# Altium schematic sheet baocaodoan.SchDoc
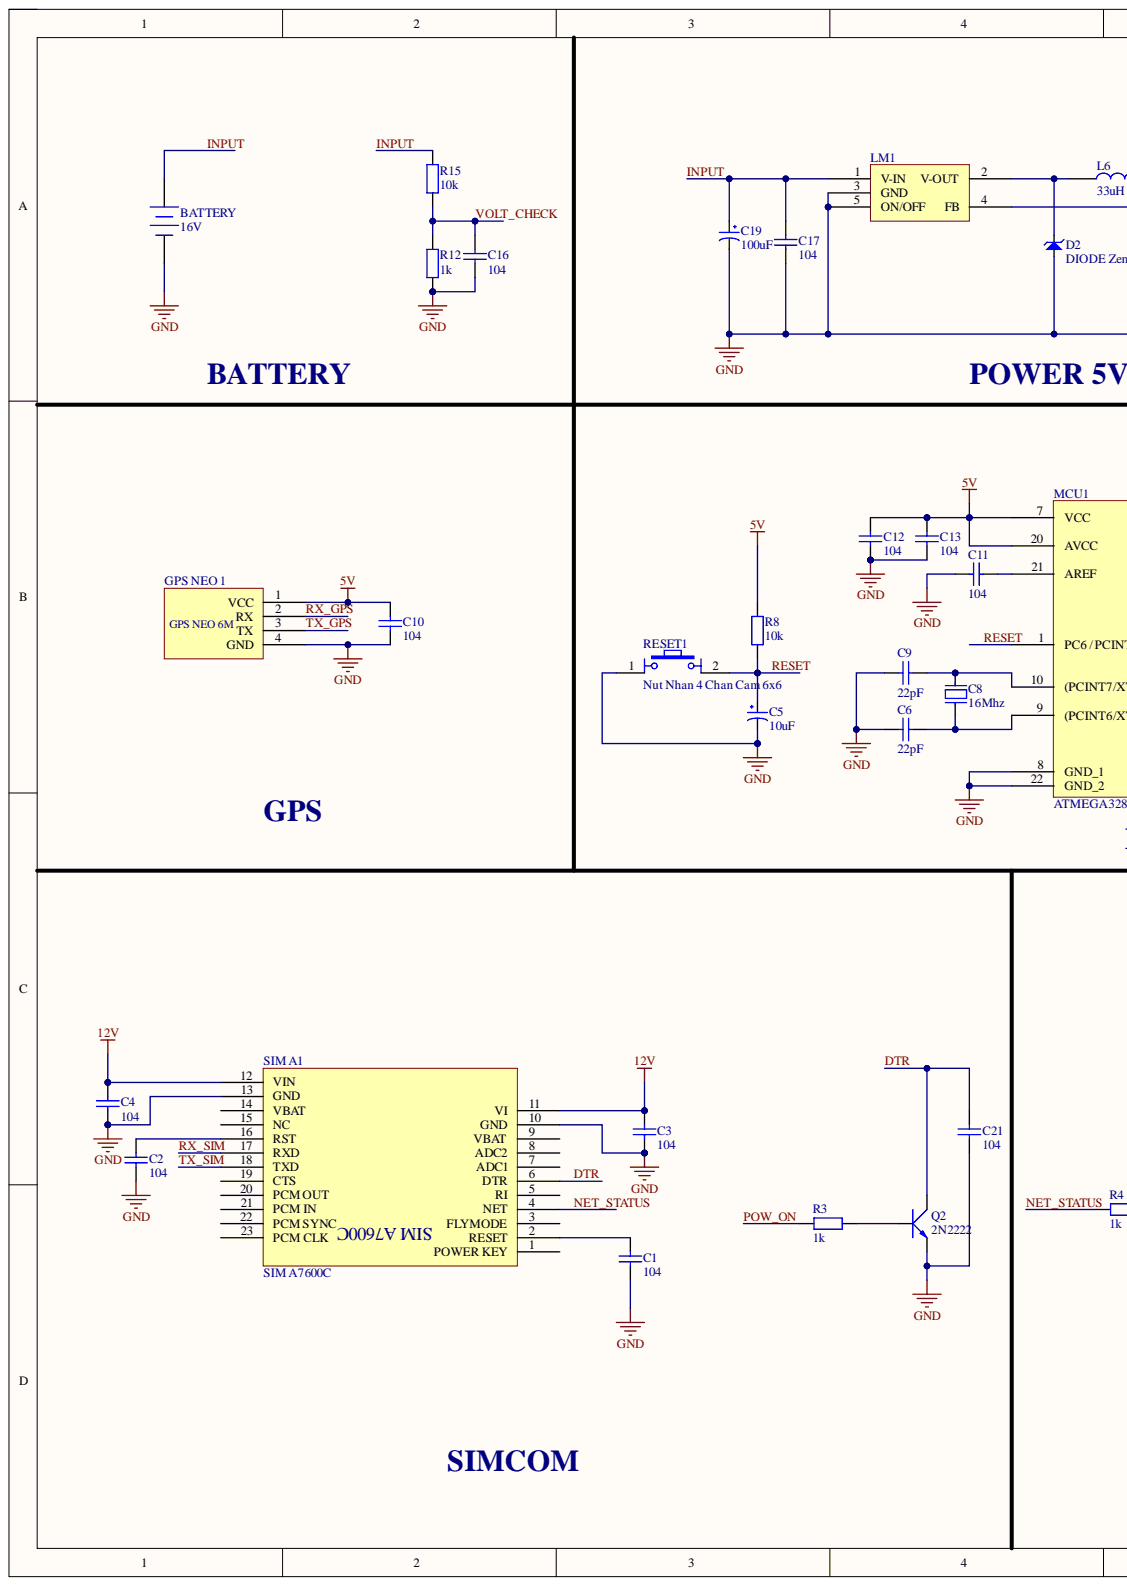
[diagram p0: baocaodoan.SchDoc, left]
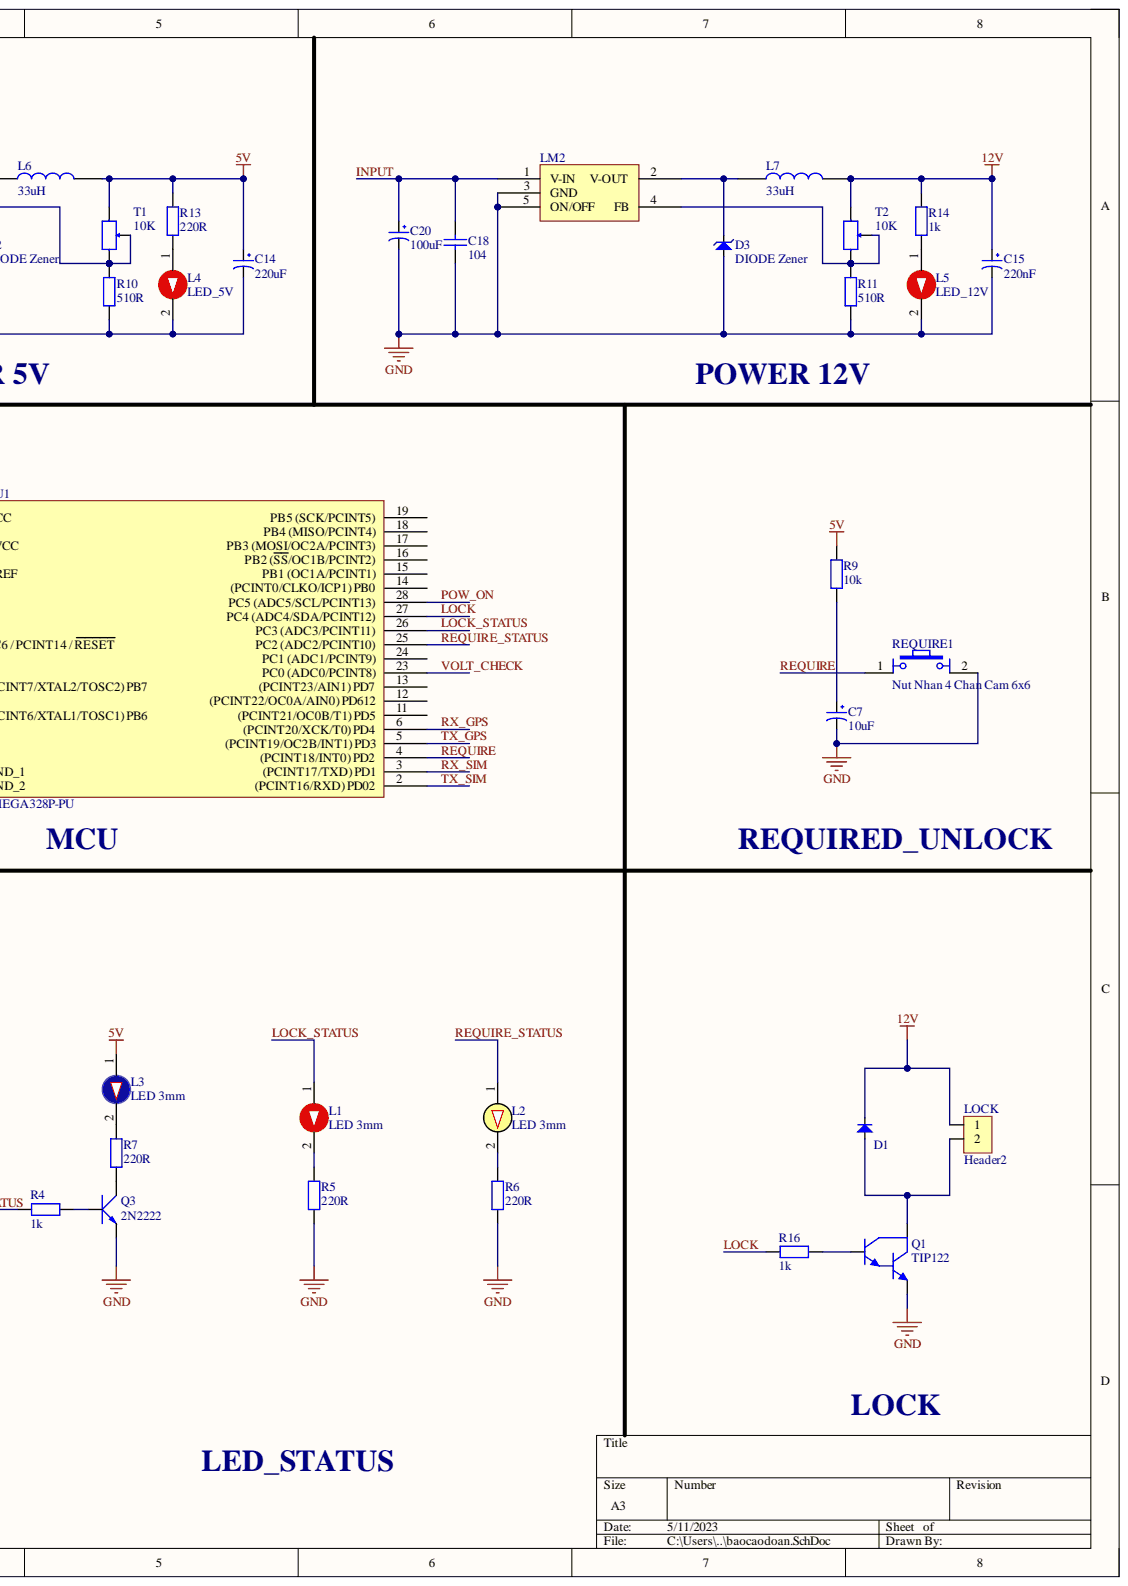
[diagram p1: baocaodoan.SchDoc, right]

## Parts
BATTERY  16V  [BAT-2]
C1  104  [tu gom]
C2  104  [tu gom]
C3  104  [tu gom]
C4  104  [tu gom]
C5  10uF  [tu hoa 4mm]
C6  22pF  [tu gom]
C7  10uF  [tu hoa 4mm]
C8  16Mhz  [Thach Anh 2 chan cam]
C9  22pF  [tu gom]
C10  104  [tu gom]
C11  104  [tu gom]
C12  104  [tu gom]
C13  104  [tu gom]
C14  220uF  [tu hoa 8mm]
C15  220nF  [tu hoa 8mm]
C16  104  [tu gom]
C17  104  [tu gom]
C18  104  [tu gom]
C19  100uF  [tu hoa 8mm]
C20  100uF  [tu hoa 8mm]
C21  104  [tu gom]
D1  Diode  [DIO-1A]  (value not drawn)
D2  DIODE Zener  [DIO-2A - LONG]
D3  DIODE Zener  [DIO-2A - LONG]
GPS_NEO_1  (no value)  [GPS NEO 6]
L1  LED 3mm  [LED-3MM-RED]
L2  LED 3mm  [LED-3MM-YELLOW]
L3  LED 3mm  [LED-3MM-BLUE]
L4  LED_5V  [LED-3MM-RED]
L5  LED_12V  [LED-3MM-RED]
L6  33uH  [CUON CAM 100uH3A]
L7  33uH  [CUON CAM 100uH3A]
LM1  (no value)  [LM2596]
LM2  (no value)  [LM2596]
LOCK  Header2  [HDR2]
MCU1  ATMEGA328P-PU  [27_DIP_7.62mm]
Q1  TIP122  [TO220-123]
Q2  2N2222  [TO92-123]
Q3  2N2222  [TO92-123]
R3  1k  [tro vach 1/4w]
R4  1k  [tro vach 1/4w]
R5  220R  [tro vach 1/4w]
R6  220R  [tro vach 1/4w]
R7  220R  [tro vach 1/4w]
R8  10k  [tro vach 1/4w]
R9  10k  [tro vach 1/4w]
R10  510R  [tro vach 1/4w]
R11  510R  [tro vach 1/4w]
R12  1k  [tro vach 1/4w]
R13  220R  [tro vach 1/4w]
R14  1k  [tro vach 1/4w]
R15  10k  [tro vach 1/4w]
R16  1k  [tro vach 1/4w]
REQUIRE1  Nut Nhan 4 Chan Cam 6x6  [Nut Nhan 4 Chan Cam 6x6]
RESET1  Nut Nhan 4 Chan Cam 6x6  [Nut Nhan 4 Chan Cam 6x6]
SIM_A1  SIM A7600C  [SIM A7600C]
T1  10K  [Bien Tro Vi Chinh Dung]
T2  10K  [Bien Tro Vi Chinh Dung]

## Nets
5V: C10.1 C12.1 C13.1 C14.1 GPS_NEO_1.1(VCC) L3.1 L6.2 MCU1.7(VCC) MCU1.20(AVCC) R8.2 R9.2 R13.2 T1.3
12V: C3.1 C4.1 C15.1 D1.2 L7.2 LOCK.1 R14.2 SIM_A1.11(VI) SIM_A1.12(VIN) T2.1
GND: BATTERY.2 C1.2 C2.2 C3.2 C4.2 C5.2 C6.2 C7.2 C9.2 C10.2 C11.2 C12.2 C13.2 C14.2 C15.2 C16.2 C17.2 C18.2 C19.2 C20.2 C21.2 D2.1 D3.1 GPS_NEO_1.4(GND) L4.2 L5.2 LM1.3(GND) LM1.5(ON/OFF) LM2.3(GND) LM2.5(ON/OFF) MCU1.8(GND_1) MCU1.22(GND_2) Q1.3 Q2.1 Q3.1 R5.1 R6.1 R10.1 R11.1 R12.1 REQUIRE1.2 RESET1.1 SIM_A1.10(GND) SIM_A1.13(GND)
DTR: C21.1 Q2.3 SIM_A1.6(DTR)
INPUT: BATTERY.1 C17.1 C18.1 C19.1 C20.1 LM1.1(V-IN) LM2.1(V-IN) R15.2
LOCK: MCU1.27(PC4_(ADC4/SDA/PCINT12)) R16.1
LOCK_STATUS: L1.1 MCU1.26(PC3_(ADC3/PCINT11))
NET_STATUS: R4.1 SIM_A1.4(NET)
POW_ON: MCU1.28(PC5_(ADC5/SCL/PCINT13)) R3.1
REQUIRE: MCU1.4((PCINT18/INT0)_PD2) REQUIRE1.1
REQUIRE_STATUS: L2.1 MCU1.25(PC2_(ADC2/PCINT10))
RESET: C5.1 MCU1.1(PC6_/_PCINT14_/_RESET) R8.1 RESET1.2
RX_GPS: GPS_NEO_1.2(RX) MCU1.6((PCINT20/XCK/T0)_PD4)
RX_SIM: MCU1.3((PCINT17/TXD)_PD1) SIM_A1.17(RXD)
TX_GPS: GPS_NEO_1.3(TX) MCU1.5((PCINT19/OC2B/INT1)_PD3)
TX_SIM: MCU1.2((PCINT16/RXD)_PD02) SIM_A1.18(TXD)
VOLT_CHECK: C16.1 MCU1.23(PC0_(ADC0/PCINT8)) R12.2 R15.1
NetC1_1: C1.1 SIM_A1.2(RESET)
NetC2_1: C2.1 SIM_A1.16(RST)
NetC6_1: C6.1 C8.1 MCU1.9((PCINT6/XTAL1/TOSC1)_PB6)
NetC7_1: C7.1 R9.1
NetC8_2: C8.2 C9.1 MCU1.10((PCINT7/XTAL2/TOSC2)_PB7)
NetC11_1: C11.1 MCU1.21(AREF)
NetD1_1: D1.1 LOCK.2 Q1.2
NetD2_2: D2.2 L6.1 LM1.2(V-OUT)
NetD3_2: D3.2 L7.1 LM2.2(V-OUT)
NetL1_2: L1.2 R5.2
NetL2_2: L2.2 R6.2
NetL3_2: L3.2 R7.2
NetL4_1: L4.1 R13.1
NetL5_1: L5.1 R14.1
NetLM1_4: LM1.4(FB) R10.2 T1.1 T1.2
NetLM2_4: LM2.4(FB) R11.2 T2.2 T2.3
NetQ1_1: Q1.1 R16.2
NetQ2_2: Q2.2 R3.2
NetQ3_2: Q3.2 R4.2
NetQ3_3: Q3.3 R7.1

Not connected: MCU1 11((PCINT21/OC0B/T1)_PD5) 12((PCINT22/OC0A/AIN0)_PD612) 13((PCINT23/AIN1)_PD7) 14((PCINT0/CLKO/ICP1)_PB0) 15(PB1_(OC1A/PCINT1)) 16(PB2_(SS/OC1B/PCINT2)) 17(PB3_(MOSI/OC2A/PCINT3)) 18(PB4_(MISO/PCINT4)) 19(PB5_(SCK/PCINT5)) 24(PC1_(ADC1/PCINT9)); SIM_A1 1(POWER_KEY) 3(FLYMODE) 5(RI) 7(ADC1) 8(ADC2) 9(VBAT) 14(VBAT) 15(NC) 19(CTS) 20(PCM_OUT) 21(PCM_IN) 22(PCM_SYNC) 23(PCM_CLK)

## Library data of the parts
BATTERY = Battery: Multicell Battery; Component Kind: Standard; LatestRevisionDate: 17-Jul-2002; LatestRevisionNote: Re-released for DXP Platform.; Library Name: Miscellaneous Devices.IntLib; PackageDescription: Battery; 2 Leads; PackageReference: BAT-2; Published: 24-Mar-1999; Publisher: Altium Limited
C1-C4 C10-C13 C16-C18 C21 = Tu gom 104 50V: Cắm; Don_Vi: Con; Ma_Hang: MH004761; So_Chan: 2; Ten_Linh_Kien: Tụ gốm 104 100nF 50V; Chung_Loai: Cắm; Component Kind: Standard; Library Name: Altium_Lib_by_KhueNguyenCreator.IntLib
C5 C7 = Tu Hoa 10uF 16V 4x7: Cắm; Don_Vi: Con; Ma_Hang: MH006855; So_Chan: 2; Ten_Linh_Kien: Tụ Hóa 10uF 16V; Chung_Loai: Cắm; Component Kind: Standard; Library Name: Altium_Lib_by_KhueNguyenCreator.IntLib
C6 C9 = Tu gom 22pF 50V: Cắm; Don_Vi: Con; Ma_Hang: MH004771; So_Chan: 2; Ten_Linh_Kien: Tụ gốm 22P 50V; Chung_Loai: Cắm; Component Kind: Standard; Library Name: Altium_Lib_by_KhueNguyenCreator.IntLib
C8 = Thach Anh 2 chan Cam: Crystal For all Crystal 2pin.; Value: 4Mhz; Component Kind: Standard; Library Name: Altium_Lib_by_KhueNguyenCreator.IntLib
C14 C15 = Tu Hoa 220uF 35V 8x12: Cắm; Don_Vi: Con; Ma_Hang: MH006553; So_Chan: 2; Ten_Linh_Kien: Tụ Hóa 220uF 35V 8x12MM; Chung_Loai: Cắm; Component Kind: Standard; Library Name: Altium_Lib_by_KhueNguyenCreator.IntLib
C19 C20 = Tu Hoa 100uF 50V 8x11: Cắm; Don_Vi: Con; Ma_Hang: MH006872; So_Chan: 2; Ten_Linh_Kien: Tụ Hóa 100uF 50V 8x11MM; Chung_Loai: Cắm; Component Kind: Standard; Library Name: Altium_Lib_by_KhueNguyenCreator.IntLib
D1 = Diode: Default Diode; Code - JEDEC: DO-214-AB; Value: 1N5007; Component Kind: Standard; Library Name: TechMT.VN_Lib_Project v2.IntLib; Published: 8-Jun-2000; Revision: July-2002: Re-released for DXP Platform.; Publisher: Altium Limited
D2 D3 = DIODE Zener: Zenner; Component Kind: Standard; Library Name: TechMT.VN_Lib_Project v2.IntLib
GPS_NEO_1 = Component_1: Component Kind: Standard; Library Name: Integrated_Library2.IntLib
L1 L4 L5 = LED 3mm Do: Cắm; Don_Vi: Con; Ma_Hang: MH003892; Ten_Linh_Kien: Led 3MM Phủ Đỏ (10c); Chung_Loai: Cắm; Component Kind: Standard; Library Name: Altium_Lib_by_KhueNguyenCreator.IntLib
L2 = LED 3mm Vang: Cắm; Don_Vi: Con; Ma_Hang: MH003893; Ten_Linh_Kien: Led 3MM Phủ Vàng (10c); Chung_Loai: Cắm; Component Kind: Standard; Library Name: Altium_Lib_by_KhueNguyenCreator.IntLib
L3 = LED 3mm Xanh Duong: Cắm; Don_Vi: Con; Ma_Hang: MH009003; Ten_Linh_Kien: LED 3MM Xanh Dương SS (1000c); Chung_Loai: Cắm; Component Kind: Standard; Library Name: Altium_Lib_by_KhueNguyenCreator.IntLib
L6 L7 = CUON CAM 470uH DIP: Cắm; Don_Vi: Con; Ma_Hang: MH002232; Ten_Linh_Kien: Cuộn Cảm 470uH 3A 8x10MM; Chung_Loai: Cắm; Component Kind: Standard; Library Name: Altium_Lib_by_KhueNguyenCreator.IntLib
LOCK = Header2: Header IDC, 2 x 1 Pin Vertical 2.54mm Pitch (Non-Boxed, Male); Manufacturer: W¨¹rth Elektronik eiSos GmbH; partNo: 613002143121; ComponentLink1 Description: Manufacturer; ComponentLink2 Description: Datasheet; NoPins: 02; Component Kind: Standard; ComponentLink1URL: http://www.we-online.com; ComponentLink2URL: http://katalog.we-online.de/em/datasheet/6130xx143121.pdf; Created Date: 29 March 2013; Library Name: TechMT.VN_Lib_Project v2.IntLib; Created by: Karrer Zheng
MCU1 = ATMEGA328P-PU: ATMEGA328P-PU; 8 bit Microcontroller AVR 20MHz 1; 32kb Flash; 2kb RAM; I2C SPI 2; Manufacturer: Microchip / Atmel; Data Bus Width: 8b; Interface: I2C,SPI,UART,USART,2-Wire; Max Supply Voltage: 5.5V; Min Supply Voltage: 1.8V; Number of ADC Channels: 6; Number of I2C Channels: 1; Number of I/Os: 23; Number of Timers/Counters: 3; Oscillator Type: Internal; Peripherals: Brown-out Detect/Reset,POR,PWM,WDT; Watchdog Timer: Yes; Case/Package: PDIP; Category: Microcontrollers; Component Kind: Standard; ComponentLink1Description: https://datasheet.ciiva.com/20168/73-4283-20168380.pdf?src-supplier=Rapid+Electronics; ComponentLink1URL: https://datasheet.ciiva.com/20168/73-4283-20168380.pdf?src-supplier=Rapid+Electronics; ComponentLink2Description: https://datasheet.ciiva.com/19457/2139111-19457070.pdf?src-supplier=Jameco; ComponentLink2URL: https://datasheet.ciiva.com/19457/2139111-19457070.pdf?src-supplier=Jameco; ComponentLink3Description: https://datasheet.ciiva.com/1157/1684409-1157696.pdf?src-supplier=Element14; ComponentLink3URL: https://datasheet.ciiva.com/1157/1684409-1157696.pdf?src-supplier=Element14; ComponentLink4Description: https://datasheet.ciiva.com/26818/atmega328p-pu-26818578.pdf?src-supplier=IIIC; ComponentLink4URL: https://datasheet.ciiva.com/26818/atmega328p-pu-26818578.pdf?src-supplier=IIIC; ComponentLink5Description: https://datasheet.ciiva.com/29119/155197-da-01-en-microcontr-atmega328p-pu-8bit-pdip-28-29119593.pdf?src-supplier=Conrad; ComponentLink5URL: https://datasheet.ciiva.com/29119/155197-da-01-en-microcontr-atmega328p-pu-8bit-pdip-28-29119593.pdf?src-supplier=Conrad; ComponentLink6Description: https://datasheet.ciiva.com/15213/getdatasheetpartid-318533-15213133.pdf?src-supplier=Verical; ComponentLink6URL: https://datasheet.ciiva.com/15213/getdatasheetpartid-318533-15213133.pdf?src-supplier=Verical; Contact Plating: Tin,Matte; Core Architecture: AVR; Frequency: 20MHz; Height: 3.28mm; Lead Free: Lead Free; Length: 37.4mm; Library Name: ATMEGA328P-PU_Library.IntLib; Manufacturer Part Number: ATMEGA328P-PU; Max Frequency: 20MHz; Max Operating Temperature: 85°C; Memory Size: 32KB; Memory Type: FLASH; Min Operating Temperature: -40°C; Mount: Through Hole; Number of Pins: 28; Number of Programmable I/O: 23; Number of PWM Channels: 6; Number of SPI Channels: 1; Package Quantity: 14; Packaging: Rail/Tube; Pricing 1: 1=2,08000, 25=1,91000, 100=1,73000 (USD); Radiation Hardening: No; RAM Size: 2KB; REACH SVHC: No SVHC; RoHS: Yes; Stock 1: 5280; Supplier 1: Digi-Key; Supplier Part Number 1: ATMEGA328P-PU-ND; Width: 6.76mm
Q1 = TIP122: Darlington Silicon Power NPN Transistor, 8 Amp, 100 Volts and 65 Watts; Component Kind: Standard; Library Name: TechMT.VN_Lib_Project v2.IntLib
Q2 Q3 = 2N222: NPN 600mW, ECB; Creat by: MT-TECH.VN; Value: C1815; Component Kind: Standard; Library Name: TechMT.VN_Lib_Project v2.IntLib
R3 R4 R16 = Tro Vach 1/4W 5% 1k: Cắm; Don_Vi: Con; Ma_Hang: MH007390; So_Chan: 2; Ten_Linh_Kien: Trở Vạch 1/4w 5% 1k; Chung_Loai: Cắm; Component Kind: Standard; Library Name: Altium_Lib_by_KhueNguyenCreator.IntLib
R5-R7 R13 R14 = Tro Vach 1/4W 5% 220R: Cắm; Don_Vi: Con; Ma_Hang: MH007300; So_Chan: 2; Ten_Linh_Kien: Trở Vạch 1/4w 5% 220R; Chung_Loai: Cắm; Component Kind: Standard; Library Name: Altium_Lib_by_KhueNguyenCreator.IntLib
R8 R9 = Tro Vach 1/4W 5% 10k: Căm; Chung_Loai: Cắm; Don_Vi: Con; Ma_Hang: MH007354; So_Chan: 2; Ten_Linh_Kien: Trở Vạch 1/4w 5% 10K; Component Kind: Standard; Library Name: Altium_Lib_by_KhueNguyenCreator.IntLib
R10 R11 = Tro Vach 1/4W 5% 510R: Cắm; Don_Vi: Con; Ma_Hang: MH007325; So_Chan: 2; Ten_Linh_Kien: Trở Vạch 1/4w 5% 510R; Chung_Loai: Cắm; Component Kind: Standard; Library Name: Altium_Lib_by_KhueNguyenCreator.IntLib
R12 = Tro Vach 1/4W 5% 1.8k: Cắm; Don_Vi: Con; Ma_Hang: MH007285; So_Chan: 2; Ten_Linh_Kien: Trở Vạch 1/4w 5% 1.8k; Chung_Loai: Cắm; Component Kind: Standard; Library Name: Altium_Lib_by_KhueNguyenCreator.IntLib
R15 = Tro Vach 1/4W 1% 10k: Cắm; Don_Vi: Con; Ma_Hang: MH006349; So_Chan: 2; Ten_Linh_Kien: Trở Vạch 1/4w 1% 10k; Chung_Loai: Cắm; Component Kind: Standard; Library Name: Altium_Lib_by_KhueNguyenCreator.IntLib
REQUIRE1 RESET1 = Nut Nhan 4 Chan Cam 6x6: Nut Nhan 4 Chan 6x6mm; Ma_Hang: MH004584; Ten: Nút Nhấn 4 Chân 6x6x5MM DIP; Component Kind: Standard; Library Name: Altium_Lib_by_KhueNguyenCreator.IntLib
T1 T2 = Bien Tro Tinh Chinh 10K Dung: Cắm; Don_Vi: Con; Ma_Hang: MH003232; Ten_Linh_Kien: Biến Trở VR 103-10K 3296W; Chung_Loai: Cắm; Component Kind: Standard; Library Name: Altium_Lib_by_KhueNguyenCreator.IntLib
LM1 LM2 = (no symbol name): Component Kind: Standard; Library Name: Integrated_Library3.IntLib
SIM_A1 = SIM A7600C: Component Kind: Standard; Library Name: Integrated_Library1.IntLib
